# Altium schematic sheet SoM-HighSpeed.SchDoc(SoM-HighSpeed)
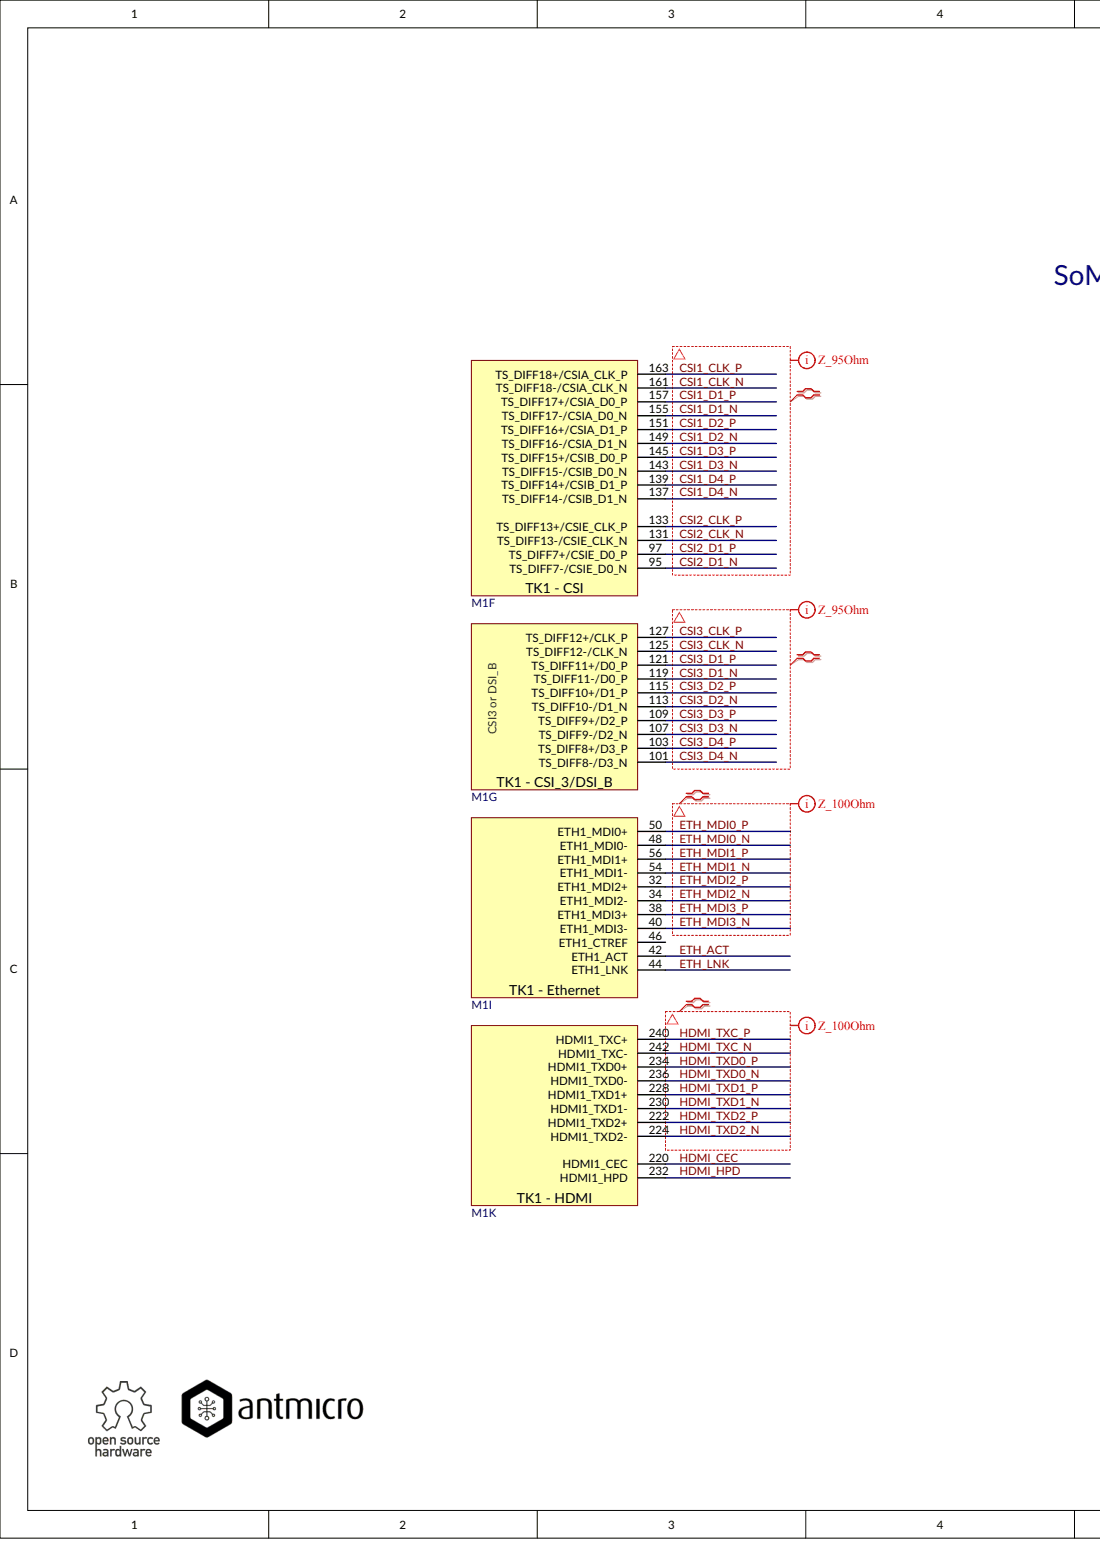
[diagram p0: SoM-HighSpeed.SchDoc, left]
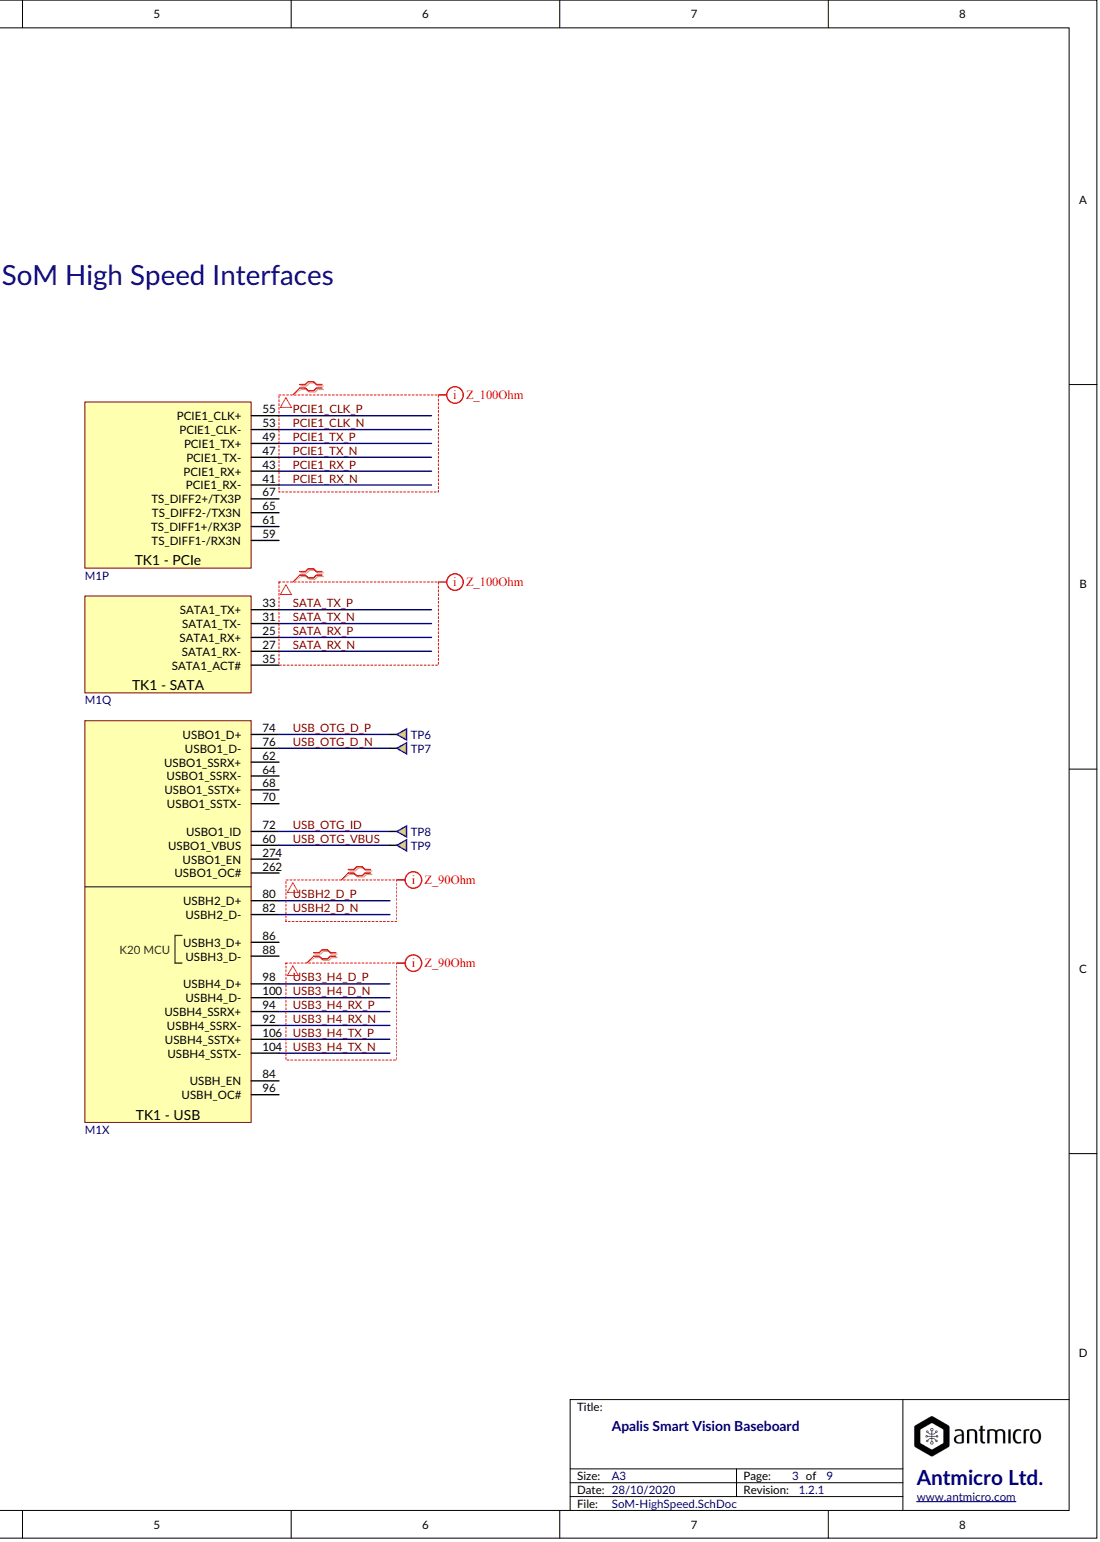
[diagram p1: SoM-HighSpeed.SchDoc, right]

## Parts
M1  MM70-314-310B1  (value not drawn)  (parts F,G,I,K,P,Q,X)
TP6  TP-R-1.5  (value not drawn)
TP7  TP-R-1.5  (value not drawn)
TP8  TP-R-1.5  (value not drawn)
TP9  TP-R-1.5  (value not drawn)

## Nets
CSI1_CLK_N: M1.161(TS_DIFF18-/CSIA_CLK_N)
CSI1_CLK_P: M1.163(TS_DIFF18+/CSIA_CLK_P)
CSI1_D1_N: M1.155(TS_DIFF17-/CSIA_D0_N)
CSI1_D1_P: M1.157(TS_DIFF17+/CSIA_D0_P)
CSI1_D2_N: M1.149(TS_DIFF16-/CSIA_D1_N)
CSI1_D2_P: M1.151(TS_DIFF16+/CSIA_D1_P)
CSI1_D3_N: M1.143(TS_DIFF15-/CSIB_D0_N)
CSI1_D3_P: M1.145(TS_DIFF15+/CSIB_D0_P)
CSI1_D4_N: M1.137(TS_DIFF14-/CSIB_D1_N)
CSI1_D4_P: M1.139(TS_DIFF14+/CSIB_D1_P)
CSI2_CLK_N: M1.131(TS_DIFF13-/CSIE_CLK_N)
CSI2_CLK_P: M1.133(TS_DIFF13+/CSIE_CLK_P)
CSI2_D1_N: M1.95(TS_DIFF7-/CSIE_D0_N)
CSI2_D1_P: M1.97(TS_DIFF7+/CSIE_D0_P)
CSI3_CLK_N: M1.125(TS_DIFF12-/CLK_N)
CSI3_CLK_P: M1.127(TS_DIFF12+/CLK_P)
CSI3_D1_N: M1.119(TS_DIFF11-/D0_P)
CSI3_D1_P: M1.121(TS_DIFF11+/D0_P)
CSI3_D2_N: M1.113(TS_DIFF10-/D1_N)
CSI3_D2_P: M1.115(TS_DIFF10+/D1_P)
CSI3_D3_N: M1.107(TS_DIFF9-/D2_N)
CSI3_D3_P: M1.109(TS_DIFF9+/D2_P)
CSI3_D4_N: M1.101(TS_DIFF8-/D3_N)
CSI3_D4_P: M1.103(TS_DIFF8+/D3_P)
ETH_ACT: M1.42(ETH1_ACT)
ETH_LNK: M1.44(ETH1_LNK)
ETH_MDI0_N: M1.48(ETH1_MDI0-)
ETH_MDI0_P: M1.50(ETH1_MDI0+)
ETH_MDI1_N: M1.54(ETH1_MDI1-)
ETH_MDI1_P: M1.56(ETH1_MDI1+)
ETH_MDI2_N: M1.34(ETH1_MDI2-)
ETH_MDI2_P: M1.32(ETH1_MDI2+)
ETH_MDI3_N: M1.40(ETH1_MDI3-)
ETH_MDI3_P: M1.38(ETH1_MDI3+)
HDMI_CEC: M1.220(HDMI1_CEC)
HDMI_HPD: M1.232(HDMI1_HPD)
HDMI_TXC_N: M1.242(HDMI1_TXC-)
HDMI_TXC_P: M1.240(HDMI1_TXC+)
HDMI_TXD0_N: M1.236(HDMI1_TXD0-)
HDMI_TXD0_P: M1.234(HDMI1_TXD0+)
HDMI_TXD1_N: M1.230(HDMI1_TXD1-)
HDMI_TXD1_P: M1.228(HDMI1_TXD1+)
HDMI_TXD2_N: M1.224(HDMI1_TXD2-)
HDMI_TXD2_P: M1.222(HDMI1_TXD2+)
PCIE1_CLK_N: M1.53(PCIE1_CLK-)
PCIE1_CLK_P: M1.55(PCIE1_CLK+)
PCIE1_RX_N: M1.41(PCIE1_RX-)
PCIE1_RX_P: M1.43(PCIE1_RX+)
PCIE1_TX_N: M1.47(PCIE1_TX-)
PCIE1_TX_P: M1.49(PCIE1_TX+)
SATA_RX_N: M1.27(SATA1_RX-)
SATA_RX_P: M1.25(SATA1_RX+)
SATA_TX_N: M1.31(SATA1_TX-)
SATA_TX_P: M1.33(SATA1_TX+)
USB3_H4_D_N: M1.100(USBH4_D-)
USB3_H4_D_P: M1.98(USBH4_D+)
USB3_H4_RX_N: M1.92(USBH4_SSRX-)
USB3_H4_RX_P: M1.94(USBH4_SSRX+)
USB3_H4_TX_N: M1.104(USBH4_SSTX-)
USB3_H4_TX_P: M1.106(USBH4_SSTX+)
USB_OTG_D_N: M1.76(USBO1_D-) TP7.1
USB_OTG_D_P: M1.74(USBO1_D+) TP6.1
USB_OTG_ID: M1.72(USBO1_ID) TP8.1
USB_OTG_VBUS: M1.60(USBO1_VBUS) TP9.1
USBH2_D_N: M1.82(USBH2_D-)
USBH2_D_P: M1.80(USBH2_D+)
N00345:
N00346:
N00347:
N00348:
N00349:
N00350:
N00351:
N00352:
N00353:
N00354:
N00355:
N00356:
N00357:
N00358:
N00359:
N00360:

Not connected: M1 35(SATA1_ACT#) 46(ETH1_CTREF) 59(TS_DIFF1-/RX3N) 61(TS_DIFF1+/RX3P) 62(USBO1_SSRX+) 64(USBO1_SSRX-) 65(TS_DIFF2-/TX3N) 67(TS_DIFF2+/TX3P) 68(USBO1_SSTX+) 70(USBO1_SSTX-) 84(USBH_EN) 86(USBH3_D+) 88(USBH3_D-) 96(USBH_OC#) 262(USBO1_OC#) 274(USBO1_EN)

## Library data of the parts
M1 = (no symbol name): Part Number: Apalis-TK1-H; Manufacturer: JAE Electronics; Part Symbol: MM70-314-310B1; Value: MM70-314-310B1; MPN: MM70-314-310B1
TP6-TP9 = (no symbol name): Part Number: TP-R-1.5
